# Altium schematic sheet RS422.SchDoc
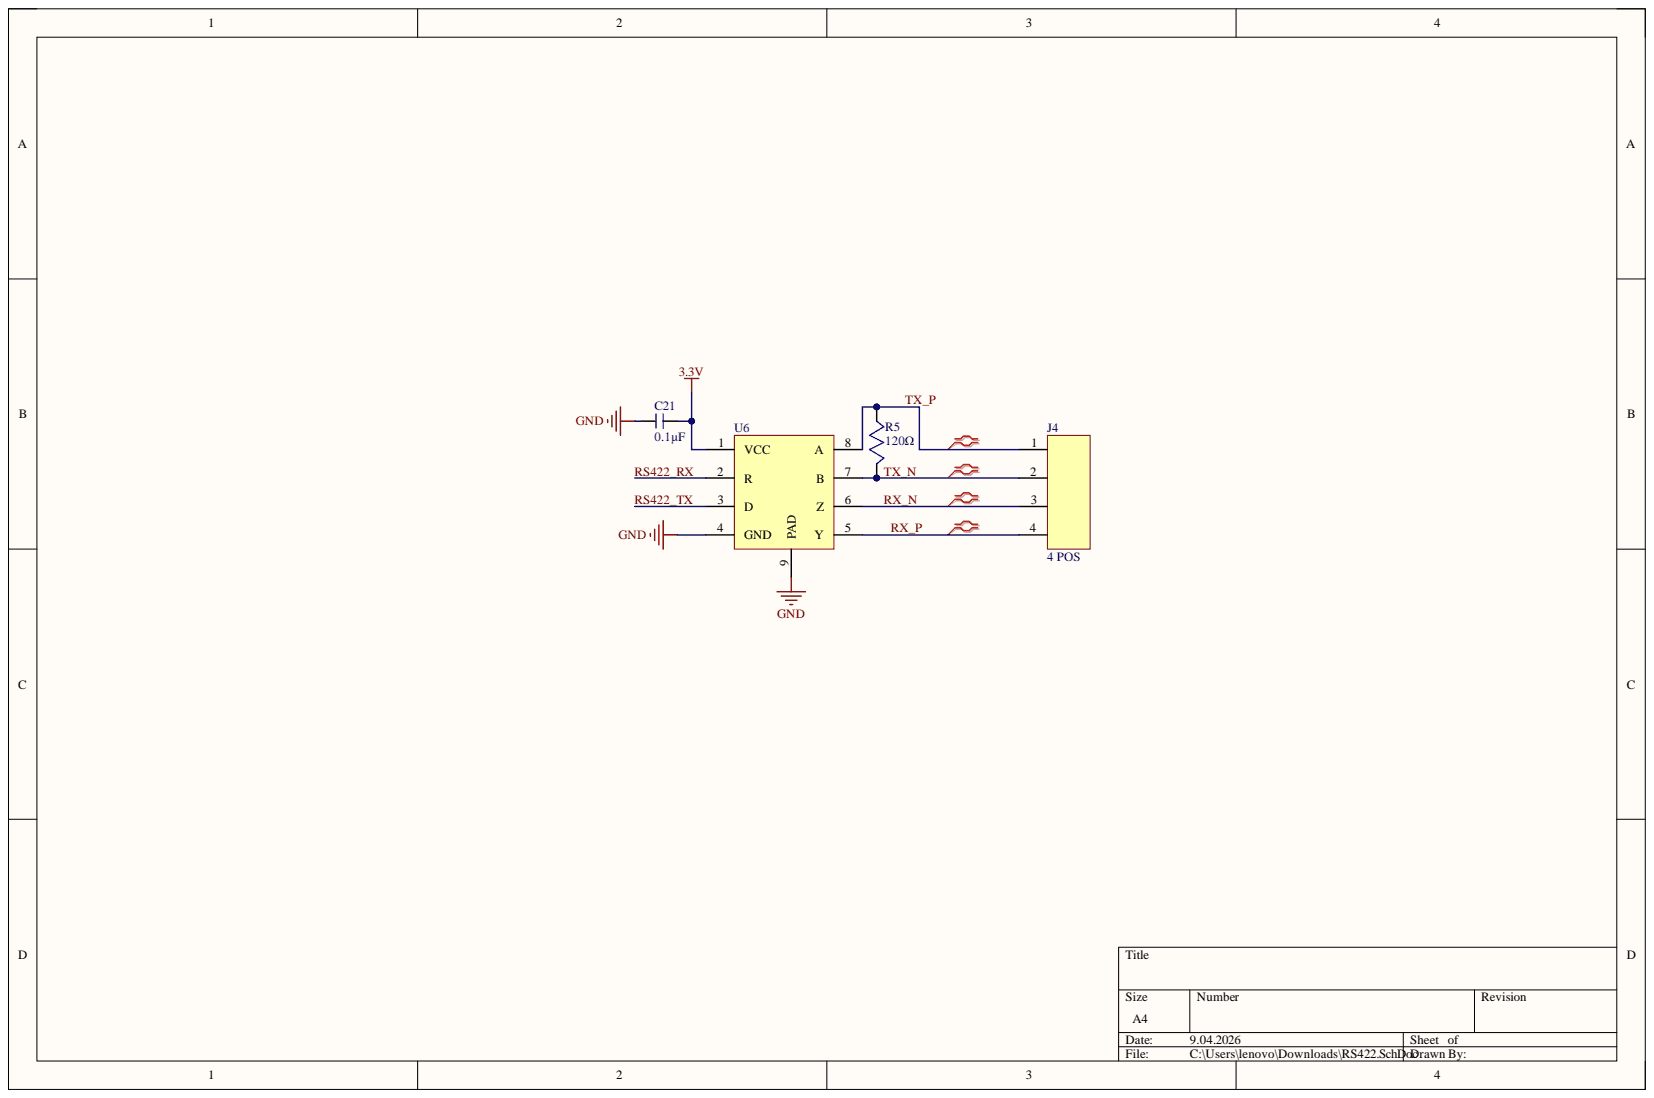
[diagram p0: RS422.SchDoc]

## Parts
C21  value 0.1µF  [402 capacitor - duplicate]  (hidden comment: 0402 capacitor)
J4  4 POS  [0901362104]
R5  value 120Ω  [402 RESISTOR]  (hidden comment: 0402. RESİSTOR)
U6  SP3077EEN-L  (value not drawn)

## Nets
3.3V: C21.1 U6.1(VCC)
GND: C21.2 U6.4(GND) U6.9(PAD)
RS422_RX: U6.2(R)
RS422_TX: U6.3(D)
RX_N: J4.3 U6.6(Z)
RX_P: J4.4 U6.5(Y)
TX_N: J4.2 R5.2 U6.7(B)
TX_P: J4.1 R5.1 U6.8(A)

## Library data of the parts
C21 = CAP SMD 402: MPN: CL05A104KA5NNNC; Component Kind: Standard; Description: CAP SMD 402; Library Name: Schlib1.SchLib; Package: 0402
J4 = 0901362104: CONN HEADER R/A 4POS 2.54MM; Component Kind: Standard; Library Name: Schlib1.SchLib; MPN: 0901362104
R5 = RES SMD 0402: MPN: ERJ-2RKF1200X; Component Kind: Standard; Description: RES SMD 0402; Library Name: Schlib1.SchLib; Package: 0402
U6 = SP3077EEN-L: IC TRANSCEIVER FULL 1/1 8SOIC; Component Kind: Standard; Library Name: Schlib1.SchLib; MPN: SP3077EEN-L
